# Altium schematic sheet 1.2.0_XBee_module.SchDoc
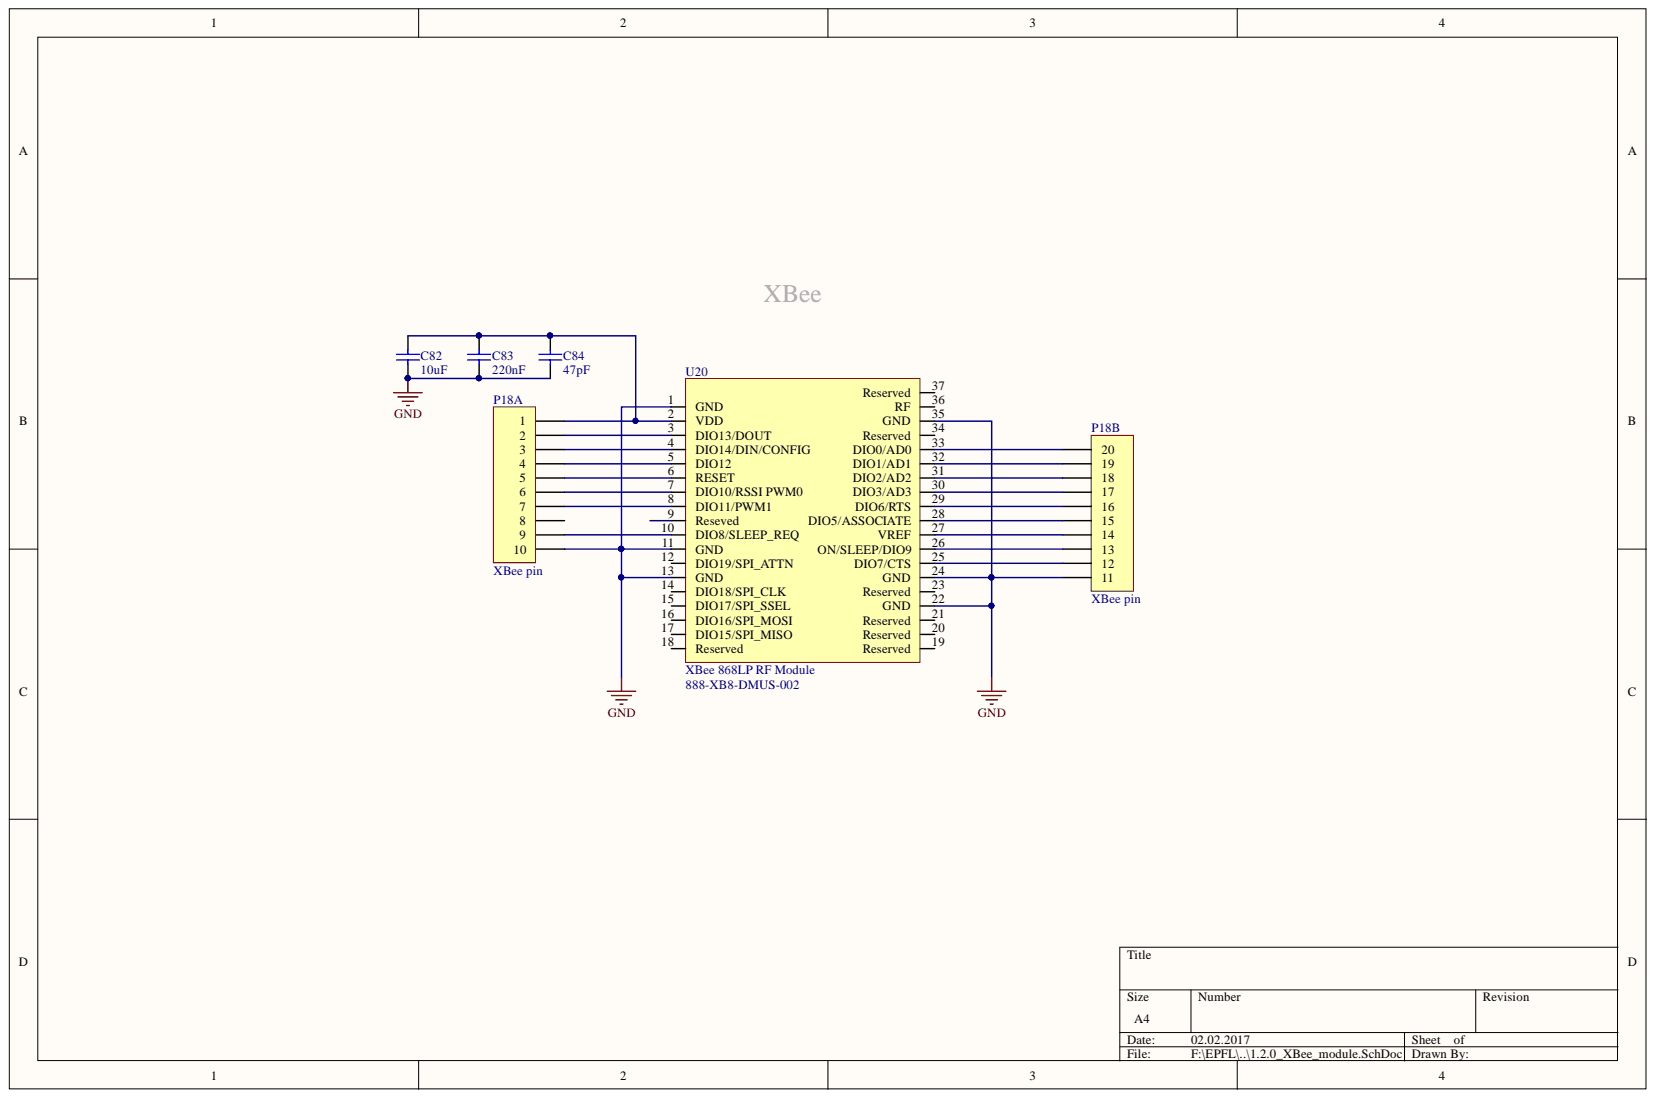
[diagram p0: 1.2.0_XBee_module.SchDoc]

## Parts
C82  10uF  [CAP0805W]
C83  220nF  [CAP0603R]
C84  47pF  [CAP0603R]
P18  XBee pin  [XBee receptacle]  (parts A,B)
U20  XBee 868LP RF Module  Supplier Part Number 1: 888-XB8-DMUS-002  [XBee 868LP RF Module]

## Nets
GND: C82.1 C83.1 C84.1 P18.10 P18.11 U20.1(GND) U20.11(GND) U20.13(GND) U20.22(GND) U20.24(GND) U20.35(GND)
NetC82_2: C82.2 C83.2 C84.2 P18.1 U20.2(VDD)
NetP18_2: P18.2 U20.3(DIO13/DOUT)
NetP18_3: P18.3 U20.4(DIO14/DIN/CONFIG)
NetP18_4: P18.4 U20.5(DIO12)
NetP18_5: P18.5 U20.6(RESET)
NetP18_6: P18.6 U20.7(DIO10/RSSI_PWM0)
NetP18_7: P18.7 U20.8(DIO11/PWM1)
NetP18_9: P18.9 U20.10(DIO8/SLEEP_REQ)
NetP18_12: P18.12 U20.25(DIO7/CTS)
NetP18_13: P18.13 U20.26(ON/SLEEP/DIO9)
NetP18_14: P18.14 U20.27(VREF)
NetP18_15: P18.15 U20.28(DIO5/ASSOCIATE)
NetP18_16: P18.16 U20.29(DIO6/RTS)
NetP18_17: P18.17 U20.30(DIO3/AD3)
NetP18_18: P18.18 U20.31(DIO2/AD2)
NetP18_19: P18.19 U20.32(DIO1/AD1)
NetP18_20: P18.20 U20.33(DIO0/AD0)

Not connected: P18 8; U20 9(Reseved) 12(DIO19/SPI_ATTN) 14(DIO18/SPI_CLK) 15(DIO17/SPI_SSEL) 16(DIO16/SPI_MOSI) 17(DIO15/SPI_MISO) 18(Reserved) 19(Reserved) 20(Reserved) 21(Reserved) 23(Reserved) 34(Reserved) 36 37(Reserved)

## Library data of the parts
C82 = C_10uF_16v: Capacitor; Component Kind: Standard; Supplier 1: Mouser; Supplier Part Number 1: 81-GRT21BC81C106ME1L; Library Name: Rocket_library.SchLib
C83 = C_220nF: Capacitor; Component Kind: Standard; Signal Integrity: Cap; Simulation: CAP; Supplier 1: Mouser; Supplier Part Number 1: 81-GCG188R91H224KA1D; Library Name: Rocket_library.SchLib
C84 = C_47pF_25V: Capacitor; Component Kind: Standard; Supplier 1: Mouser; Supplier Part Number 1: 77-VJ0603A470JXXPBC; Library Name: Rocket_library.SchLib
P18 = XBee pin: Component Kind: Standard; Description: XBee pin; Library Name: Rocket_library.SchLib
U20 = XBee 868LP RF Module: Component Kind: Standard; Description: XBee 868LP RF Module; Supplier 1: Mouser; Library Name: Rocket_library.SchLib
